# Altium schematic sheet POWERSTAGE.SchDoc(POWERSTAGE)
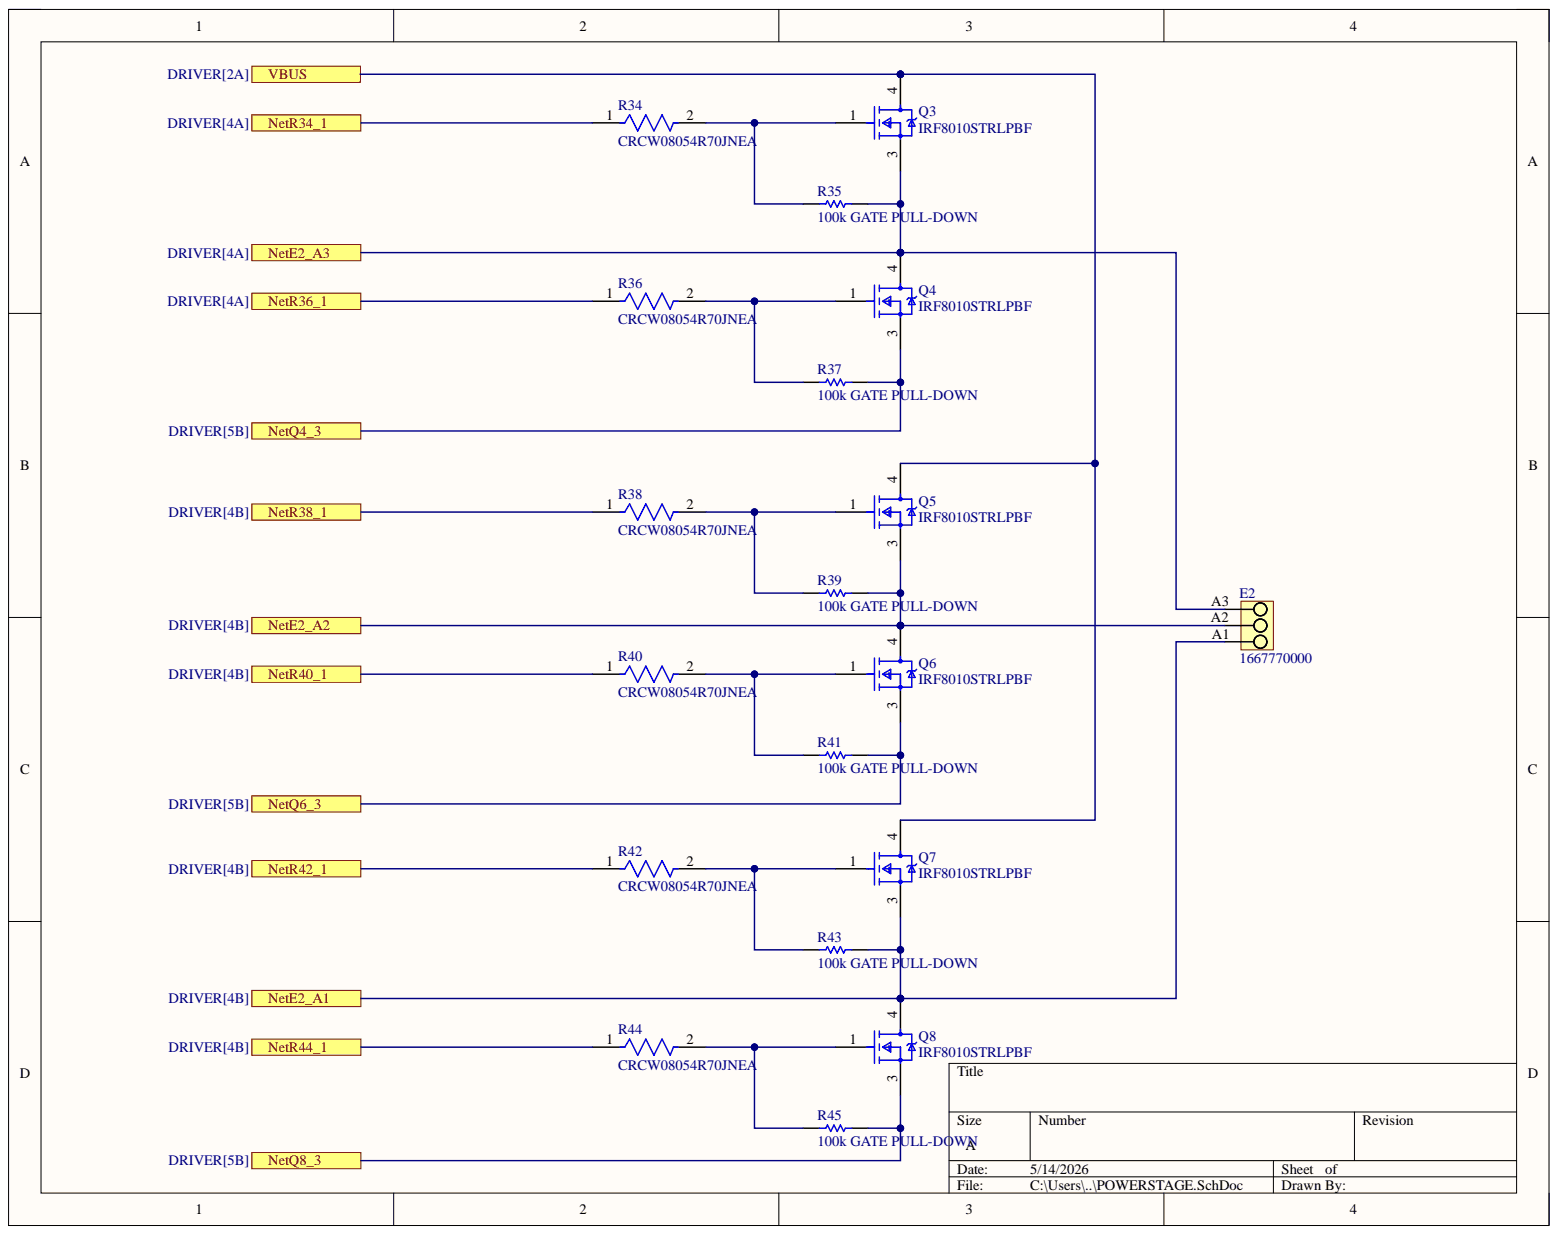
[diagram p0: POWERSTAGE.SchDoc]

## Parts
E2  1667770000  [LM_3_5_90_03]
Q3  IRF8010STRLPBF  [FP-PG-TO263-3-MFG]
Q4  IRF8010STRLPBF  [FP-PG-TO263-3-MFG]
Q5  IRF8010STRLPBF  [FP-PG-TO263-3-MFG]
Q6  IRF8010STRLPBF  [FP-PG-TO263-3-MFG]
Q7  IRF8010STRLPBF  [FP-PG-TO263-3-MFG]
Q8  IRF8010STRLPBF  [FP-PG-TO263-3-MFG]
R34  CRCW08054R70JNEA  [FP-CRCW0805-e3-IPC_B]
R35  100k GATE PULL-DOWN  [RESC0603(1608)_N]
R36  CRCW08054R70JNEA  [FP-CRCW0805-e3-IPC_B]
R37  100k GATE PULL-DOWN  [RESC0603(1608)_N]
R38  CRCW08054R70JNEA  [FP-CRCW0805-e3-IPC_B]
R39  100k GATE PULL-DOWN  [RESC0603(1608)_N]
R40  CRCW08054R70JNEA  [FP-CRCW0805-e3-IPC_B]
R41  100k GATE PULL-DOWN  [RESC0603(1608)_N]
R42  CRCW08054R70JNEA  [FP-CRCW0805-e3-IPC_B]
R43  100k GATE PULL-DOWN  [RESC0603(1608)_N]
R44  CRCW08054R70JNEA  [FP-CRCW0805-e3-IPC_B]
R45  100k GATE PULL-DOWN  [RESC0603(1608)_N]

## Ports
GHA, GHB, GHC, GLA, GLB, GLC, SHA, SHB, SHC, SPA, SPB, SPC, VDRAIN

## Nets
VDRAIN: Q3.4 Q5.4 Q7.4 ; port VDRAIN
NetE2_A1: E2.A1 Q7.3 Q8.4 R43.2 ; port SHC
NetE2_A2: E2.A2 Q5.3 Q6.4 R39.2 ; port SHB
NetE2_A3: E2.A3 Q3.3 Q4.4 R35.2 ; port SHA
NetQ3_1: Q3.1 R34.2 R35.1
NetQ4_1: Q4.1 R36.2 R37.1
NetQ4_3: Q4.3 R37.2 ; port SPA
NetQ5_1: Q5.1 R38.2 R39.1
NetQ6_1: Q6.1 R40.2 R41.1
NetQ6_3: Q6.3 R41.2 ; port SPB
NetQ7_1: Q7.1 R42.2 R43.1
NetQ8_1: Q8.1 R44.2 R45.1
NetQ8_3: Q8.3 R45.2 ; port SPC
NetR34_1: R34.1 ; port GHA
NetR36_1: R36.1 ; port GLA
NetR38_1: R38.1 ; port GHB
NetR40_1: R40.1 ; port GLB
NetR42_1: R42.1 ; port GHC
NetR44_1: R44.1 ; port GLC

## Library data of the parts
E2 = CMP-1559-01729-1: OMNIMATE Signal - series LM; Manufacturer: Weidmueller; Contact_material: Cu-Leg; Contact_surface: tinned; Insulating_material: PA; Mounting_onto_the_PCB: Solder connection; No_of_poles: 3; Pitch_inch: 0,138 inch; Pitch_mm: 3,5 mm; Rated_current_min_no_of_poles_TU_20_deg_C: 32 A; Rated_current_use_group_B_UL_1059: 10 A; Rated_voltage_for_surge_voltage_class_pollution_degree_II_2: 320 V; Rated_voltage_use_group_B_UL_1059: 300 V; Short_text_for_material: LM 3.50/03/90 3.2SN OR BX; Side_termination_characteristic: closed side; Solder_pin_length_mm: 3,2 mm; Solid_max_H05_07_V_U: 1,5 mm²; Solid_min_H05_07_V_U: 0,5 mm²; UL_94_flammability_rating: V-2; Wire_cross_section_AWG_max_UL_1059: AWG 14; Wire_cross_section_AWG_min_UL_1059: AWG 28; Colour: Orange; Component Kind: Standard; ComponentLink1Description: Manufacturer URL; ComponentLink1URL: http://www.weidmueller.com/; ComponentLink2Description: URL Link1; ComponentLink2URL: http://catalog.weidmueller.com/catalog/Start.do?localeId=en&ObjectID=1667770000; Library Name: Altium Content Vault; PackageReference: LM_3_5_90_03; Packaging: Box; PartNumber: 1667770000; Qty: 100 PCS; Revision: V1
Q3-Q8 = CMP-32901-000596-1: MOSFET N-CH 100V 80A D2PAK; Drain to Source Resistance: 15mR; Element Configuration: Single; Gate to Source Voltage (Vgs): 20V; Input Capacitance: 3.83nF; Max Power Dissipation: 260W; Rise Time: 130ns; Threshold Voltage: 4V; Turn-Off Delay Time: 61ns; Turn-On Delay Time: 15ns; Case/Package: D2PAK; Component Kind: Standard; Contact Plating: Tin; Continuous Drain Current (ID): 80A; Drain to Source Voltage (Vdss): 100V; Halogen Free: Halogen Free; Height: 0.19inch; Lead Free: Contains Lead; Length: 0.42inch; Library Name: Altium Content Vault; Max Dual Supply Voltage: 100V; Max Operating Temperature: 175°C; Min Operating Temperature: -55°C; Mount: Surface Mount; Number of Pins: 3; Packaging: Tape and Reel; Rds On Max: 15mR; REACH SVHC: No SVHC; RoHS Compliant: Yes; Width: 0.38inch
R34 R36 R38 R40 R42 R44 = CMP-2001-03983-2: RES Thick Film, 4.7Ω, 5%, 0.125W, 200ppm/°C, 0805; Max Power Dissipation: 125mW; Resistance: 4.7R; Voltage Rating: 150V; Case Code (Imperial): 0805; Case Code (Metric): 2012; Case/Package: 0805; Component Kind: Standard; Composition: Thick Film; Contact Plating: Tin; Depth: 1.25mm; Features: Automotive AEC-Q200; Height: 0.45mm; Lead Free: Lead Free; Length: 2mm; Library Name: Altium Content Vault; Max Operating Temperature: 155°C; Military Standard: Not; Min Operating Temperature: -55°C; Number of Pins: 2; Number of Terminations: 2; Package Quantity: 5000; Packaging: Tape and Reel; Power Rating: 125mW; Radiation Hardening: No; Temperature Coefficient: 200ppm/°C; Termination: SMD/SMT; Tolerance: 5%; Voltage Rating (DC): 150V; Weight: 0.000194oz; Width: 0.049inch; Working Voltage: 150V
R35 R37 R39 R41 R43 R45 = CMP-1012-00122-1: 100K 0.1W 5% 0603 (1608 Metric)  SMD; Case-EIA: 0603; Case-Metric: 1608; Value: 100000.00 Ohm; Component Kind: Standard; Library Name: Altium Content Vault; Power: 0.1 W; Technology: SMT; Tolerance: 5 %
